# Altium schematic sheet 5_Keys_And_Status.SchDoc
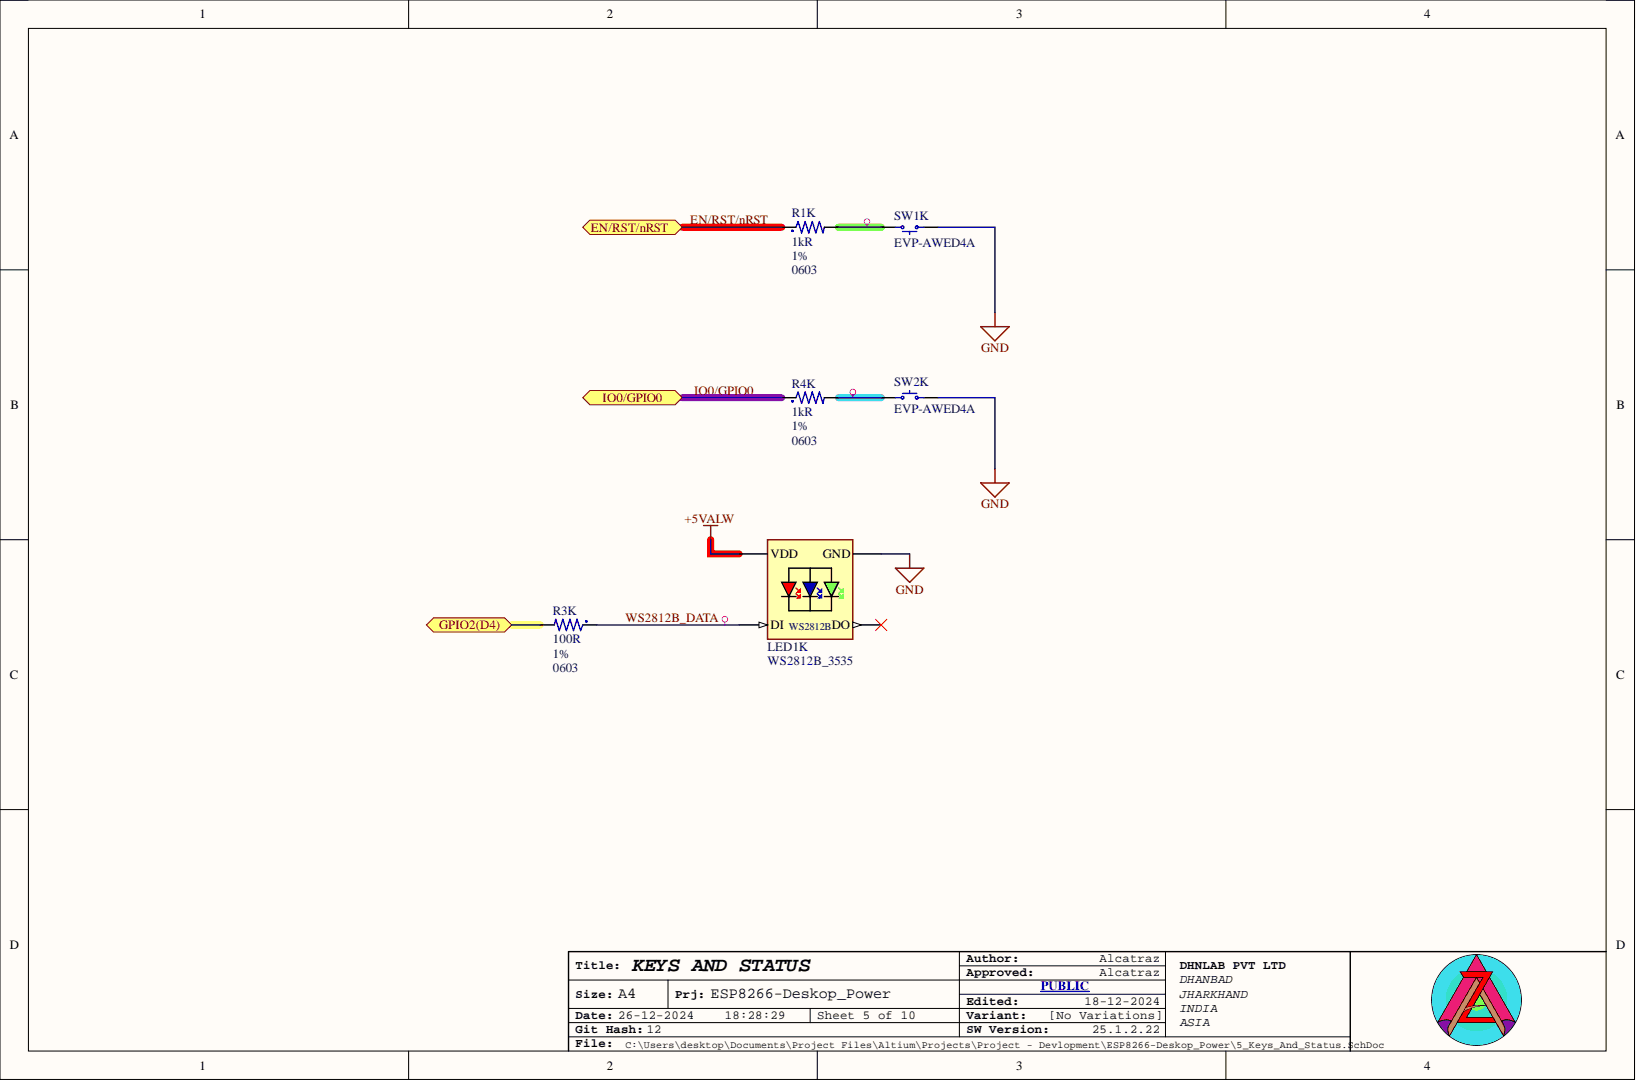
[diagram p0: 5_Keys_And_Status.SchDoc]

## Parts
LED1K  WS2812B_3535  MP: WS2812B  [WS2812B-Mini_3535]
R1K  value 1kR  Resistance Tolerance: 1%  [R0603-MFG]  (hidden comment: RC0603FR-101KL)
R3K  value 100R  Tolerance: 1%  [R0603-MFG]  (hidden comment: AC0603FR-07100RL)
R4K  value 1kR  Resistance Tolerance: 1%  [R0603-MFG]  (hidden comment: RC0603FR-101KL)
SW1K  EVP-AWED4A  [PANASONIC EVPAW]
SW2K  EVP-AWED4A  [PANASONIC EVPAW]

## Ports
EN/RST/nRST, GPIO2(D4), IO0/GPIO0

## Nets
+5VALW: LED1K.1(VDD)
GND: LED1K.3(GND) SW1K.1 SW2K.2
EN/RST/nRST: R1K.1 ; port EN/RST/nRST
GPIO2(D4): R3K.2 ; port GPIO2(D4)
IO0/GPIO0: R4K.1 ; port IO0/GPIO0
WS2812B_DATA: LED1K.4(DI) R3K.1
NetR1K_2: R1K.2 SW1K.2
NetR4K_2: R4K.2 SW2K.1

Not connected: LED1K 2(DO)

## Library data of the parts
LED1K = WS2812B_3535: 4 PIN SMART LED  WS2812 BODY 3.5MM X 3.5MM; MF: SparkFun Electronics; Availability: Unavailable; Component Kind: Standard; Library Name: Lib_LED.IntLib; Package: None; Price: None; SnapEDA_Link: https://www.snapeda.com/parts/WS2812B/Worldsemi/view-part/1038297/?ref=snap
R1K R4K = 1K: SMD Chip Resistor, 1 kOhm, ± 1%, 100 mW, 0603 [1608 Metric], Thick Film, General Purpose; Manufacturer: Yageo; Power Rating: 100mW; Temperature Coefficient: 100ppm/°C; Voltage Rating: 50V; Case Code (Imperial): 0603; Case Code (Metric): 1608; Case/Package: 0603; Category: Chip SMD Resistors; Component Kind: Standard; ComponentLink1Description: https://datasheet.ciiva.com/7983/443971-7983659.pdf?src-supplier=Element14; ComponentLink1URL: https://datasheet.ciiva.com/7983/443971-7983659.pdf?src-supplier=Element14; ComponentLink2Description: https://datasheet.ciiva.com/12560/rc0603-272842-12560633.pdf?src-supplier=Mouser; ComponentLink2URL: https://datasheet.ciiva.com/12560/rc0603-272842-12560633.pdf?src-supplier=Mouser; Contact Plating: Tin,Lead; Height: 0.45mm; Length: 1.6mm; Library Name: Lib_Register_0603.IntLib; Manufacturer Part Number: RC0603FR-101KL; Max Operating Temperature: 155°C; Min Operating Temperature: -55°C; Packaging: Tape and Reel; Pins: 2NUMBER; Pricing 1: 1=0.0018 (USD); Reach SVHC Compliant: Unknown; Resistor Type: Thick Film; RoHS: Yes; RoHS Compliant: Yes; Series: RC; Stock 1: 4; Supplier 1: Arrow Electronics; Supplier Part Number 1: RC0603FR-101KL; Termination: SMD/SMT; Tolerance: 1%; Voltage Rating (DC): 50V; Width: 0.8mm
R3K = 100R: SMD Chip Resistor, 100 Ohm, ± 1%, 100 mW, 0603 [1608 Metric], Thick Film, General Purpose; Manufacturer: Yageo; Max Power Dissipation: 100mW; Temperature Coefficient: 100ppm/°C; Voltage Rating: 50V; Case Code (Imperial): 0603; Case Code (Metric): 1608; Case/Package: 0603; Category: Chip SMD Resistors; Component Kind: Standard; ComponentLink1Description: https://datasheet.ciiva.com/12576/pyu-ac-51-rohs-l-2-12576586.pdf?src-supplier=Mouser; ComponentLink1URL: https://datasheet.ciiva.com/12576/pyu-ac-51-rohs-l-2-12576586.pdf?src-supplier=Mouser; Contact Plating: Tin,Matte; Features: Moisture Resistant,Automotive AEC-Q200; Height: 0.45mm; Lead Free: 5697LeadFree; Length: 1.6mm; Library Name: Lib_Register_0603.IntLib; Manufacturer Part Number: AC0603FR-07100RL; Max Operating Temperature: 155°C; Min Operating Temperature: -55°C; Number of Terminations: 2; Packaging: Tape and Reel; Power Rating: 100mW; Pricing 1: 5000=0.0027, 50000=0.0025, 150000=0.0024, 375000=0.0023, 1000000=0.0022 (USD); Ratings: AEC-Q200; RoHS: Yes; RoHS Compliant: Yes; Series: AC; Stock 1: 1675000; Supplier 1: Future Electronics; Supplier Part Number 1: 2026405; Termination: SMD/SMT; Weight: 7.1E-05oz; Width: 0.8mm
SW1K SW2K = EVP-AWED4A: 3.0MM X 2.0MM / 3.3N / 0.15MM ST; Manufacturer: Panasonic Electronic Components; Actuator Height off PCB, Vertical: 0.60mm; Actuator Orientation: Top Actuated; Actuator Type: Standard; Circuit: SPST-NO; Contact Rating @ Voltage: 0.02A @ 15VDC; Database Table Name: Switches - Tactile; Illumination: Non-Illuminated; Ingress Protection: IP67 - Dust Tight, Waterproof; Operating Force: 330gf; Outline: 3.00mm x 2.00mm; Switch Function: Off-Mom; Component Kind: Standard; ComponentLink1Description: Datasheet; ComponentLink1URL: https://industrial.panasonic.com/ac/cdn/e/control/switch/light-touch/catalog/sw_lt_eng_3020s.pdf; ComponentLink2Description: DigiKey Link; ComponentLink2URL: https://www.digikey.com/en/products/detail/panasonic-electronic-components/EVP-AWED4A/8568275; LastUpdated: 03-06-2024 20:19:31; Library Name: Celestial Altium Library - altium_library.DbLib; Lifecycle Status: Active; Minimum Order: 1; Mounting Type: Surface Mount; Operating Temperature: -40°C ~ 85°C; Packaging: TapeAndReel; Part Number: EVP-AWED4A; Part Status: Active; Price: 0.16; Series: EVPAW; Supplier 1: DigiKey; Supplier Part Number 1: P123440CT-ND; Termination Style: SMD (SMT) Tab
